# Altium schematic sheet Metal sensor.SchDoc
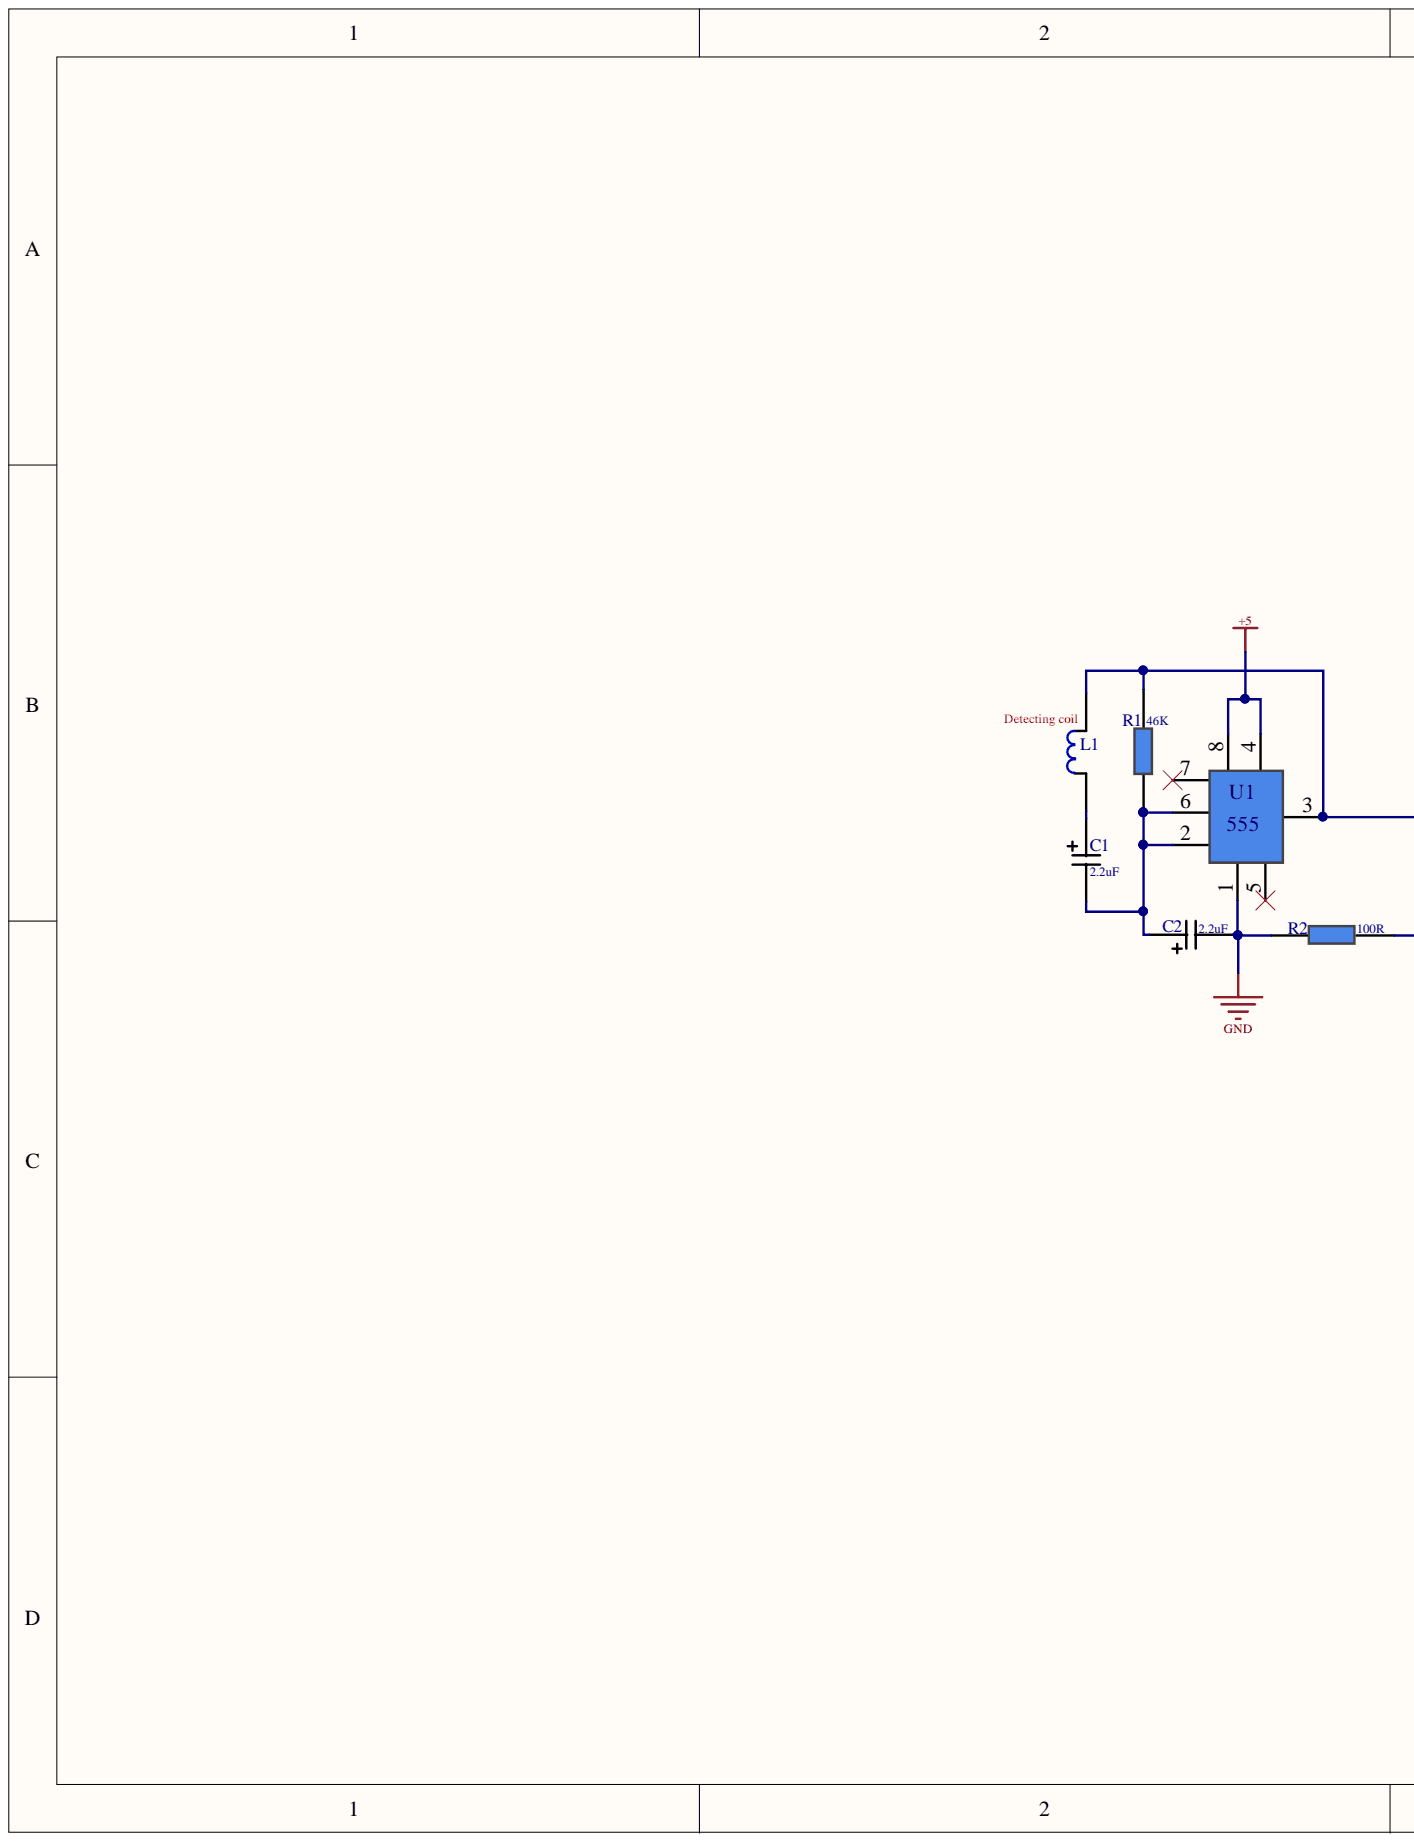
[diagram p0: Metal sensor.SchDoc, left]
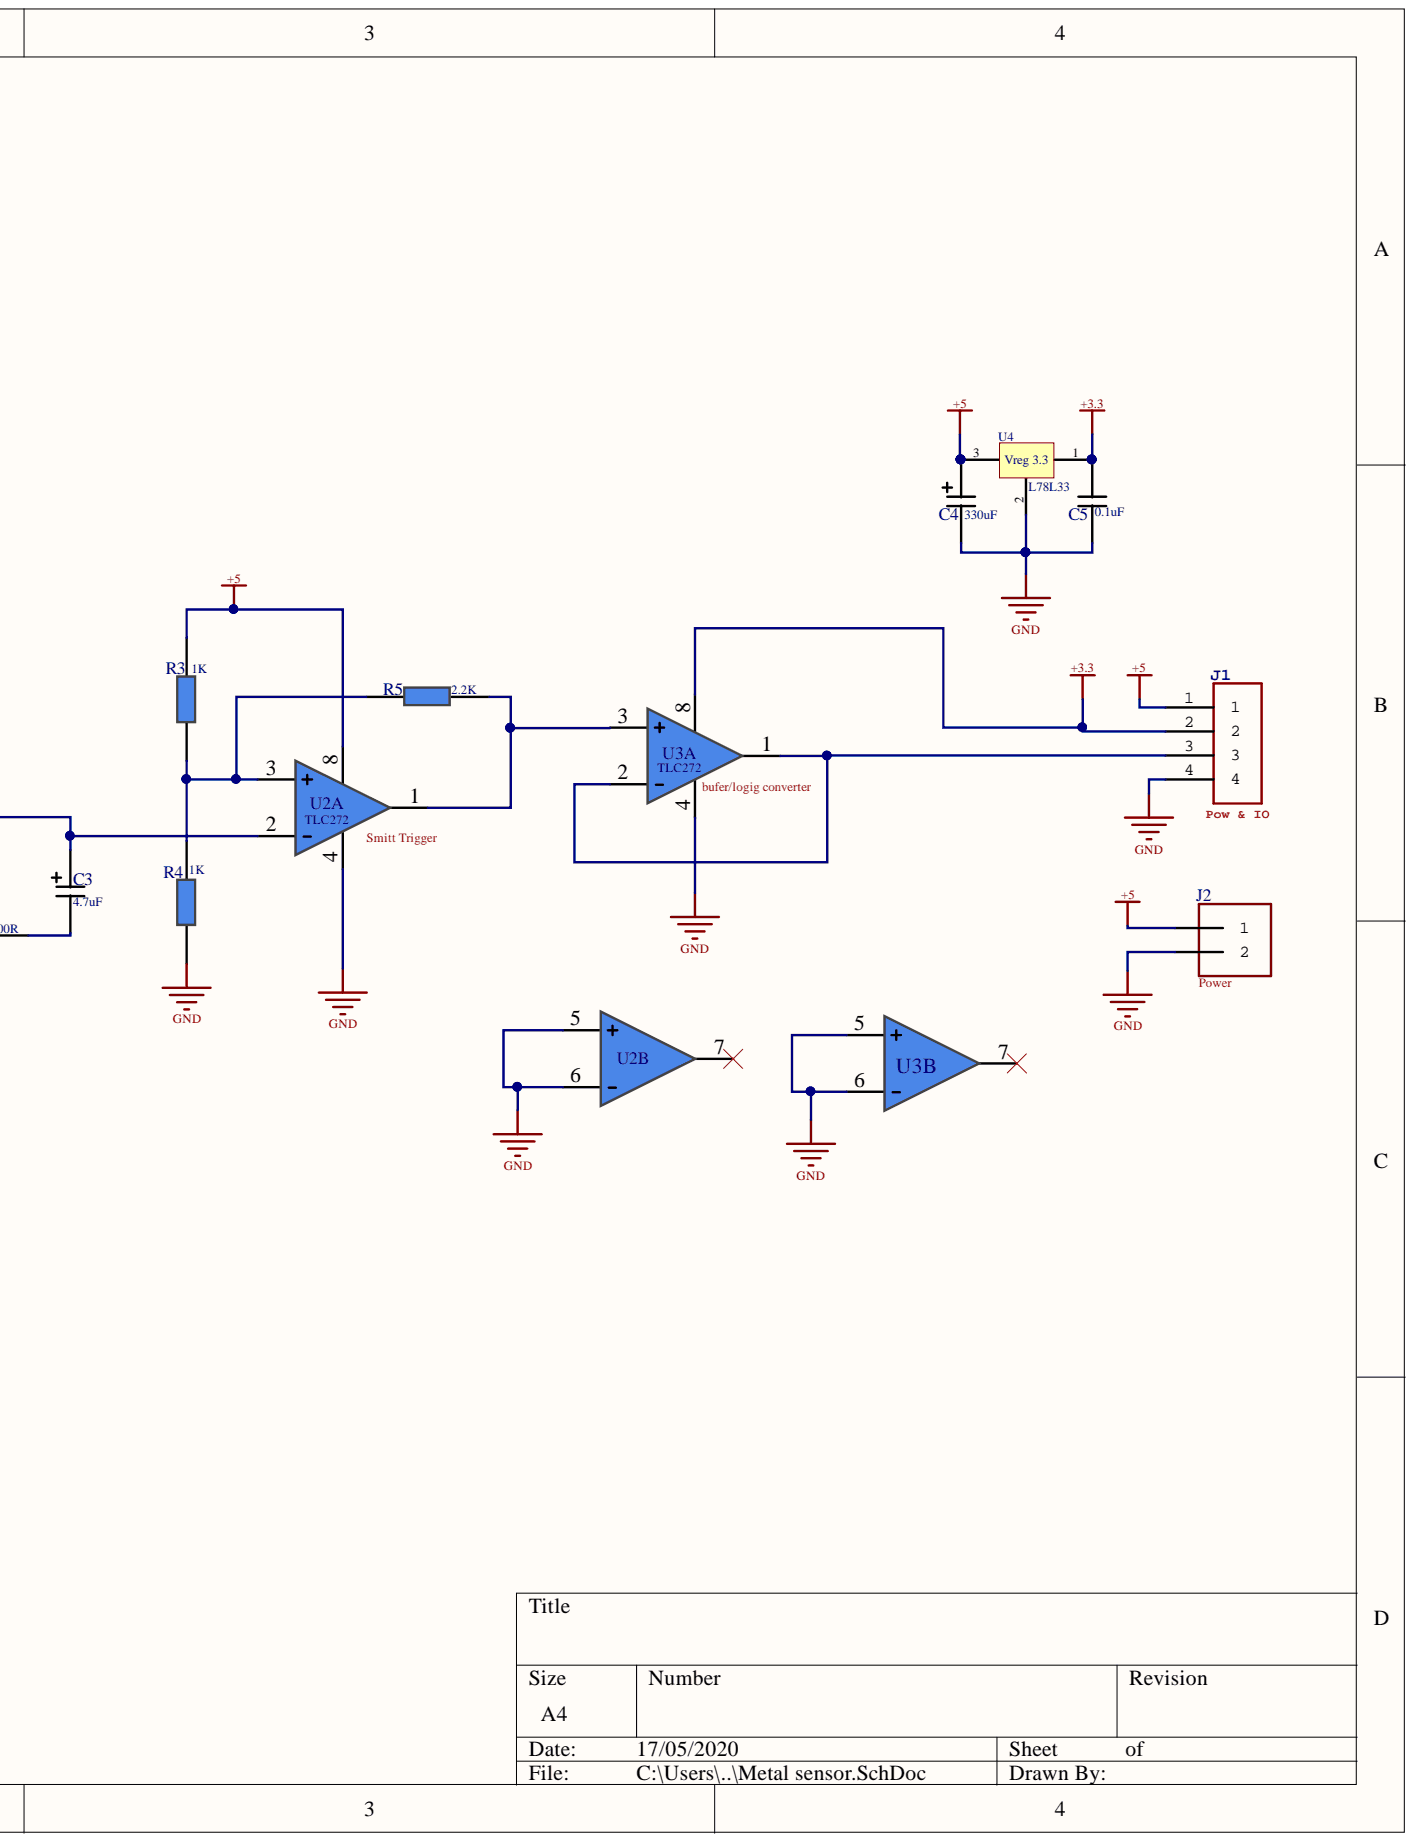
[diagram p1: Metal sensor.SchDoc, right]

## Parts
C1  2.2uF  [cap elect]
C2  2.2uF  [cap elect]
C3  4.7uF  [cap elect]
C4  330uF  [cap elect]
C5  0.1uF  [cap ceram]
J1  Pow & IO  [1X04-3.5MM]
J2  Power  [1X2-3.5MM]
L1  coil  [1X2-3.5MM]
R1  46K  [Resistor]
R2  100R  [Resistor]
R3  1K  [Resistor]
R4  1K  [Resistor]
R5  2.2K  [Resistor]
U1  555  [DIP8]
U2  TLC272  [DIP8]  (parts A,B)
U3  TLC272  [DIP8]  (parts A,B)
U4  L78L33  [TO92-INLINE]

## Nets
+3.3: C5.2 J1.2 U3.8 U4.1
+5: C4.2 J1.1 J2.1 R3.2 U1.4 U1.8 U2.8 U4.3
GND: C4.1 C5.1 J1.4 J2.2 R2.1 R4.1 U2.4 U2.5 U2.6 U3.4 U3.5 U3.6 U4.2
NetC1_1: C1.1 C2.2 R1.1 U1.2 U1.6
NetC1_2: C1.2 L1.1
NetC2_1: C2.1 U1.1
NetC3_1: C3.1 R2.2
NetC3_2: C3.2 L1.2 R1.2 U1.3 U2.2
NetJ1_3: J1.3 U3.1 U3.2
NetR3_1: R3.1 R4.2 R5.1 U2.3
NetR5_2: R5.2 U2.1 U3.3

Not connected: U1 5 7; U2 7; U3 7

## Library data of the parts
C1-C4 = capacitor_electrolitic: Component Kind: Standard; Library Name: IoTProject.SchLib
C5 = capacitor_ceramic: Component Kind: Standard; Library Name: IoTProject.SchLib
J1 = 1X4-3.5MM: Component Kind: Standard; Library Name: adafruit.IntLib
J2 = 1X2-3.5MM: Component Kind: Standard; Library Name: adafruit.IntLib
R1-R5 = resistor: Component Kind: Standard; Library Name: IoTProject.SchLib
U1 = 555timer: Component Kind: Standard; Library Name: IoTProject.SchLib
U2 U3 = op-amp: Component Kind: Standard; Library Name: IoTProject.SchLib
U4 = Regulator: Component Kind: Standard; Library Name: IoTProject.SchLib
L1 = coil: Component Kind: Standard; Library Name: IoTProject.SchLib
